# Altium schematic sheet AS5600.SchDoc
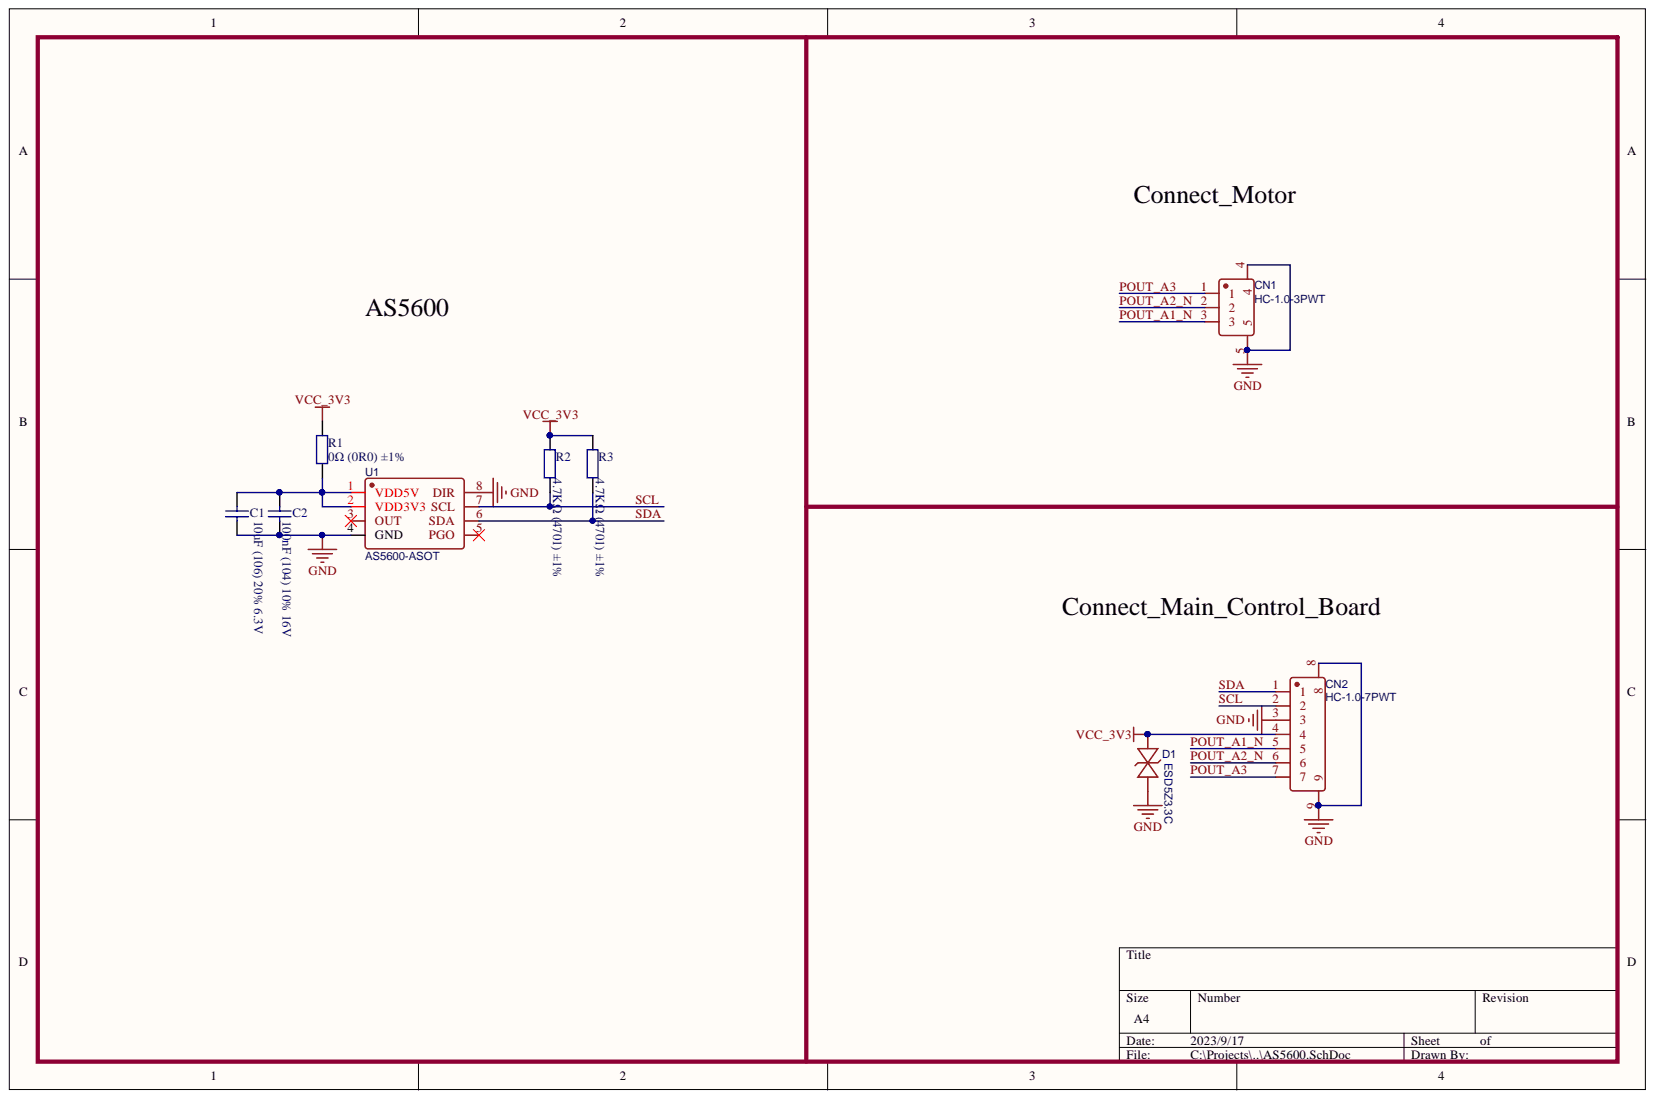
[diagram p0: AS5600.SchDoc]

## Parts
C1  10uF (106) 20% 6.3V  [0402_C]
C2  100nF (104) 10% 16V  [0402_C]
CN1  HC-1.0-3PWT  [CONN-SMD_3P-P1.0-HC-1.0-3PWT]
CN2  HC-1.0-7PWT  [CONN-SMD_HC-1.0-7PWT]
D1  ESD5Z3.3C  [SOD-523_L1.2-W0.8-LS1.6-BI]
R1  0Ω (0R0) ±1%  [0402_R]
R2  4.7KΩ (4701) ±1%  [0402_R]
R3  4.7KΩ (4701) ±1%  [0402_R]
U1  AS5600-ASOT  [SOIC-8_L5.0-W4.0-P1.27-LS6.0-BL]

## Nets
GND: C1.1 C2.1 CN1.4 CN1.5 CN2.3 CN2.8 CN2.9 D1.2 U1.4(GND) U1.8(DIR)
VCC_3V3: CN2.4 D1.1 R1.2 R2.2 R3.2
POUT_A1_N: CN1.3 CN2.5
POUT_A2_N: CN1.2 CN2.6
POUT_A3: CN1.1 CN2.7
SCL: CN2.2 R2.1 U1.7(SCL)
SDA: CN2.1 R3.1 U1.6(SDA)
NetC1_2: C1.2 C2.2 R1.1 U1.1(VDD5V) U1.2(VDD3V3)

Not connected: U1 3(OUT) 5(PGO)

## Library data of the parts
C1 = 0402 10uF (106) 20% 6.3V: 贴片电容; Component Kind: Standard; ComponentLink1Description: 供应商链接; ComponentLink1URL: http://www.szlcsc.com/product/details_16204.html; Library Name: Miscellaneous Devices LC.IntLib; Package: 0402; Supplier: LC; SuppliersPartNumber: C15525
C2 = 0402 100nF (104) 10% 16V: 贴片电容; Component Kind: Standard; ComponentLink1Description: 供应商链接; ComponentLink1URL: http://www.szlcsc.com/product/details_1877.html; Library Name: Miscellaneous Devices LC.IntLib; Package: 0402; Supplier: LC; SuppliersPartNumber: C1525
CN1 = HC-1.0-3PWT: BOM_Manufacturer: HCTL(华灿天禄); Contributor: LCSC; BOM_Manufacturer Part: HC-1.0-3PWT; BOM_Supplier: LCSC; BOM_Supplier Part: C2845362; Component Kind: Standard; spicePre: C; spiceSymbolName: HC-1.0-3PWT; Library Name: chaoning_Integrated_Library.IntLib
CN2 = HC-1.0-7PWT: BOM_Manufacturer: HCTL(华灿天禄); Contributor: LCSC; BOM_Manufacturer Part: HC-1.0-7PWT; BOM_Supplier: LCSC; BOM_Supplier Part: C2845366; Component Kind: Standard; spicePre: C; spiceSymbolName: HC-1.0-7PWT; Library Name: chaoning_Integrated_Library.IntLib
D1 = ESD5Z3.3C: BOM_Manufacturer: MDD（Microdiode Electronics）; Contributor: LCSC; BOM_JLCPCB Part Class: Extended Part; BOM_Manufacturer Part: ESD5Z3.3C; BOM_Supplier: LCSC; BOM_Supplier Part: C502551; Component Kind: Standard; spicePre: D; spiceSymbolName: ESD5Z3.3C; Library Name: chaoning_Integrated_Library.IntLib
R1 = 0402 0Ω (0R0) 1%: 贴片电阻; Component Kind: Standard; ComponentLink1Description: 供应商链接; ComponentLink1URL: http://www.szlcsc.com/product/details_17853.html; Library Name: Miscellaneous Devices LC.IntLib; Package: 0402; Supplier: LC; SuppliersPartNumber: C17168
R2 R3 = 0402 4.7KΩ (4701) 1%: 贴片电阻; Component Kind: Standard; ComponentLink1Description: 供应商链接; ComponentLink1URL: http://www.szlcsc.com/product/details_26643.html; Library Name: Miscellaneous Devices LC.IntLib; Package: 0402; Supplier: LC; SuppliersPartNumber: C25900
U1 = AS5600-ASOT: BOM_Manufacturer: Advanced Monolithic Systems; Contributor: LCSC; BOM_JLCPCB Part Class: Extended Part; BOM_Manufacturer Part: AS5600-ASOT; BOM_Supplier: LCSC; BOM_Supplier Part: C499458; Component Kind: Standard; spicePre: U; spiceSymbolName: AS5600-ASOT; Library Name: chaoning_Integrated_Library.IntLib
